# Altium schematic sheet Linefollower_sensor.SchDoc
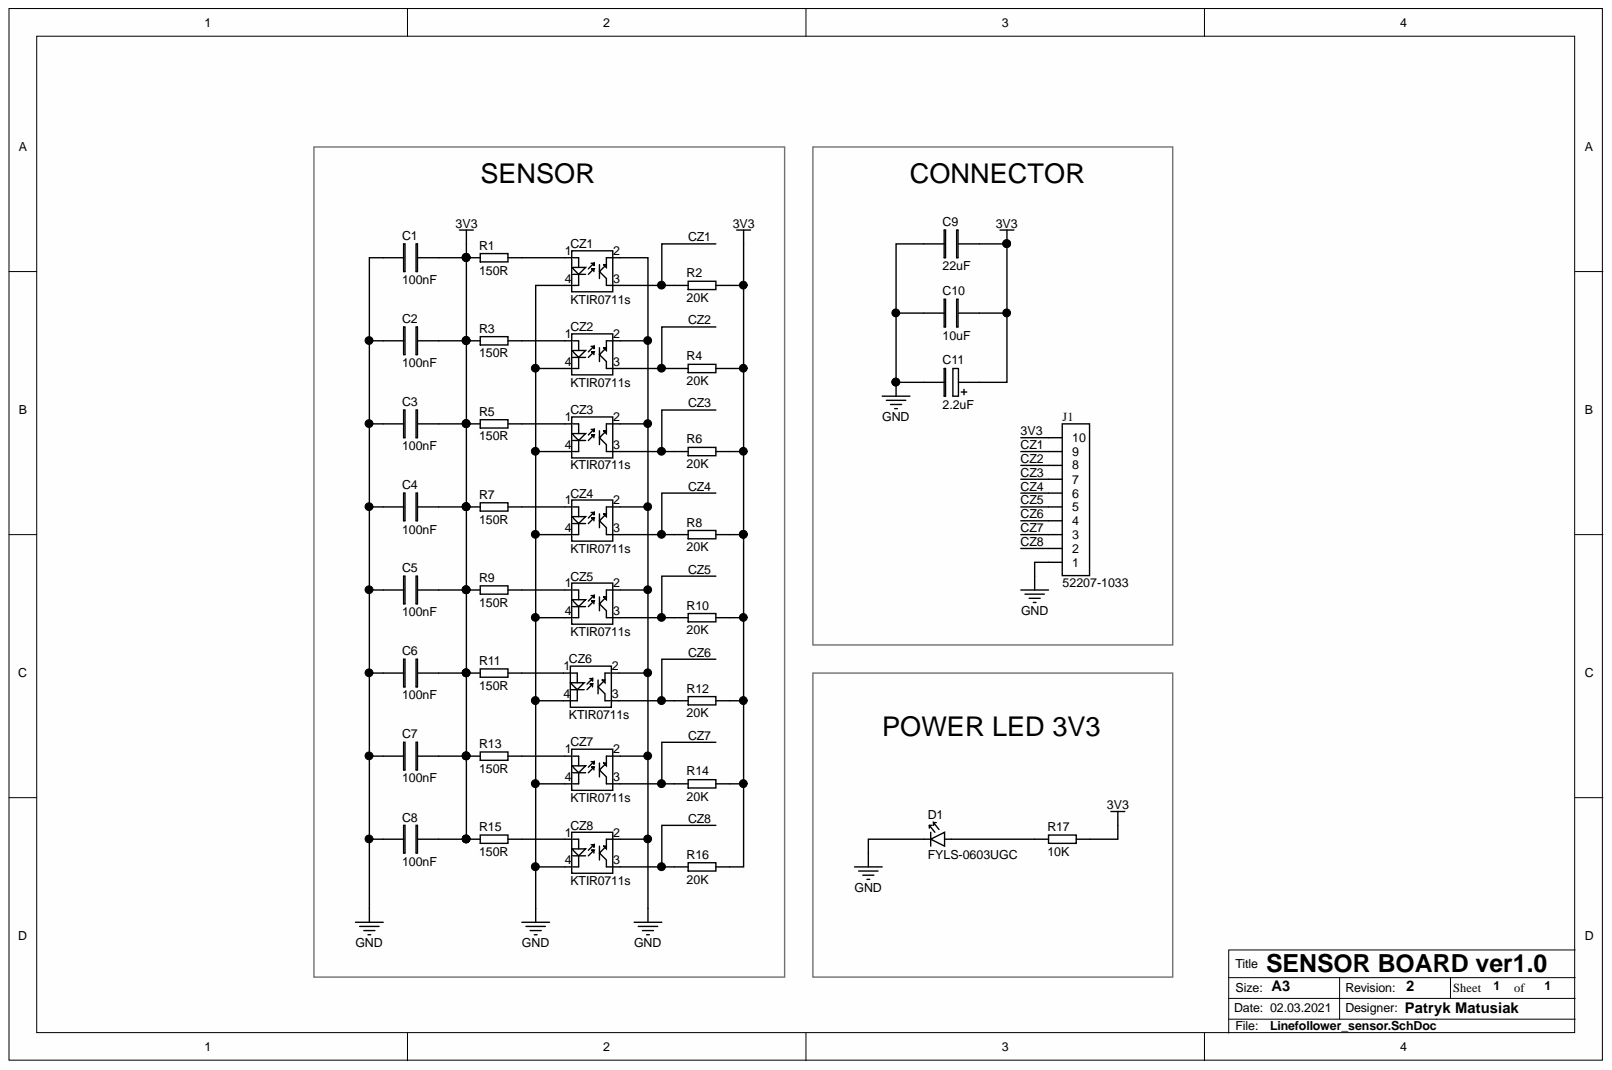
[diagram p0: Linefollower_sensor.SchDoc]

## Parts
C1  value 100nF  [CAP0603]  (hidden comment: 100nF  0603)
C2  value 100nF  [CAP0603]  (hidden comment: 100nF  0603)
C3  value 100nF  [CAP0603]  (hidden comment: 100nF  0603)
C4  value 100nF  [CAP0603]  (hidden comment: 100nF  0603)
C5  value 100nF  [CAP0603]  (hidden comment: 100nF  0603)
C6  value 100nF  [CAP0603]  (hidden comment: 100nF  0603)
C7  value 100nF  [CAP0603]  (hidden comment: 100nF  0603)
C8  value 100nF  [CAP0603]  (hidden comment: 100nF  0603)
C9  value 22uF  [CAP1206]  (hidden comment: 22uF  0603)
C10  value 10uF  [CAP0603]  (hidden comment: 10uF  0603)
C11  value 2.2uF  [SMD_A]  (hidden comment: 2.2uF  SMD_A)
CZ1  Own  KTIR0711s  [KTIR0711s]  (value not drawn)
CZ2  Own  KTIR0711s  [KTIR0711s]  (value not drawn)
CZ3  Own  KTIR0711s  [KTIR0711s]  (value not drawn)
CZ4  Own  KTIR0711s  [KTIR0711s]  (value not drawn)
CZ5  Own  KTIR0711s  [KTIR0711s]  (value not drawn)
CZ6  Own  KTIR0711s  [KTIR0711s]  (value not drawn)
CZ7  Own  KTIR0711s  [KTIR0711s]  (value not drawn)
CZ8  Own  KTIR0711s  [KTIR0711s]  (value not drawn)
D1  Part_number: FYLS-0603UGC  [DIODE0603_GREEN]  (hidden comment: 0603  FYLS-0603UGC)
J1  Part_number: 52207-1033  [MOLEX FFC 1mm 10 PIN]  (hidden comment: PIN10, SMD, 1mm  52207-1033)
R1  value 150R  [Res0603]  (hidden comment: 150R  0603)
R2  value 20K  [Res0603]  (hidden comment: 20K  0603)
R3  value 150R  [Res0603]  (hidden comment: 150R  0603)
R4  value 20K  [Res0603]  (hidden comment: 20K  0603)
R5  value 150R  [Res0603]  (hidden comment: 150R  0603)
R6  value 20K  [Res0603]  (hidden comment: 20K  0603)
R7  value 150R  [Res0603]  (hidden comment: 150R  0603)
R8  value 20K  [Res0603]  (hidden comment: 20K  0603)
R9  value 150R  [Res0603]  (hidden comment: 150R  0603)
R10  value 20K  [Res0603]  (hidden comment: 20K  0603)
R11  value 150R  [Res0603]  (hidden comment: 150R  0603)
R12  value 20K  [Res0603]  (hidden comment: 20K  0603)
R13  value 150R  [Res0603]  (hidden comment: 150R  0603)
R14  value 20K  [Res0603]  (hidden comment: 20K  0603)
R15  value 150R  [Res0603]  (hidden comment: 150R  0603)
R16  value 20K  [Res0603]  (hidden comment: 20K  0603)
R17  value 10K  [Res0603]  (hidden comment: 10K  0603)

## Nets
GND: C1.2 C2.2 C3.2 C4.2 C5.2 C6.2 C7.2 C8.2 C9.2 C10.2 C11.2 CZ1.2 CZ1.4 CZ2.2 CZ2.4 CZ3.2 CZ3.4 CZ4.2 CZ4.4 CZ5.2 CZ5.4 CZ6.2 CZ6.4 CZ7.2 CZ7.4 CZ8.2 CZ8.4 D1.1 J1.1
3V3: C1.1 C2.1 C3.1 C4.1 C5.1 C6.1 C7.1 C8.1 C9.1 C10.1 C11.1 J1.10 R1.1 R2.2 R3.1 R4.2 R5.1 R6.2 R7.1 R8.2 R9.1 R10.2 R11.1 R12.2 R13.1 R14.2 R15.1 R16.2 R17.2
CZ1: CZ1.3 J1.9 R2.1
CZ2: CZ2.3 J1.8 R4.1
CZ3: CZ3.3 J1.7 R6.1
CZ4: CZ4.3 J1.6 R8.1
CZ5: CZ5.3 J1.5 R10.1
CZ6: CZ6.3 J1.4 R12.1
CZ7: CZ7.3 J1.3 R14.1
CZ8: CZ8.3 J1.2 R16.1
NetCZ1_1: CZ1.1 R1.2
NetCZ2_1: CZ2.1 R3.2
NetCZ3_1: CZ3.1 R5.2
NetCZ4_1: CZ4.1 R7.2
NetCZ5_1: CZ5.1 R9.2
NetCZ6_1: CZ6.1 R11.2
NetCZ7_1: CZ7.1 R13.2
NetCZ8_1: CZ8.1 R15.2
NetD1_2: D1.2 R17.1

## Library data of the parts
C1-C10 = Capacitor: Component Kind: Standard; Description: Capacitor; Library Name: Library.IntLib; Package: 0603
C11 = Capacitor_Polarity: Component Kind: Standard; Description: Capacitor_Polarity; Library Name: Library.IntLib; Package: SMD_A
CZ1-CZ8 = KTIR0711s: Photo Interrupter Phototransistor SMD; Manufacturer: Kingbright; Component Kind: Standard; Library Name: Device.SchLib; Package: Own; Part_number: KTIR0711s
D1 = DiodeLED_0603_green: LED; SMD; 0603; green 40mcd; 1,6x0,8x0,8mm; 130°; 2÷2.6V; Manufacturer: Foryard; Component Kind: Standard; Library Name: Diode.SchLib; Package: 0603
J1 = Molex FFC 1mm 10 PIN: Connector Molex FFC 1mm 10 PIN; Component Kind: Standard; Library Name: Connector.SchLib; Manufacturer: Molex; Package: PIN10, SMD, 1mm
R1-R17 = Resistor: Component Kind: Standard; Description: Resistor; Library Name: Library.IntLib; Package: 0603
